# Altium schematic sheet PowerSupplies.SchDoc
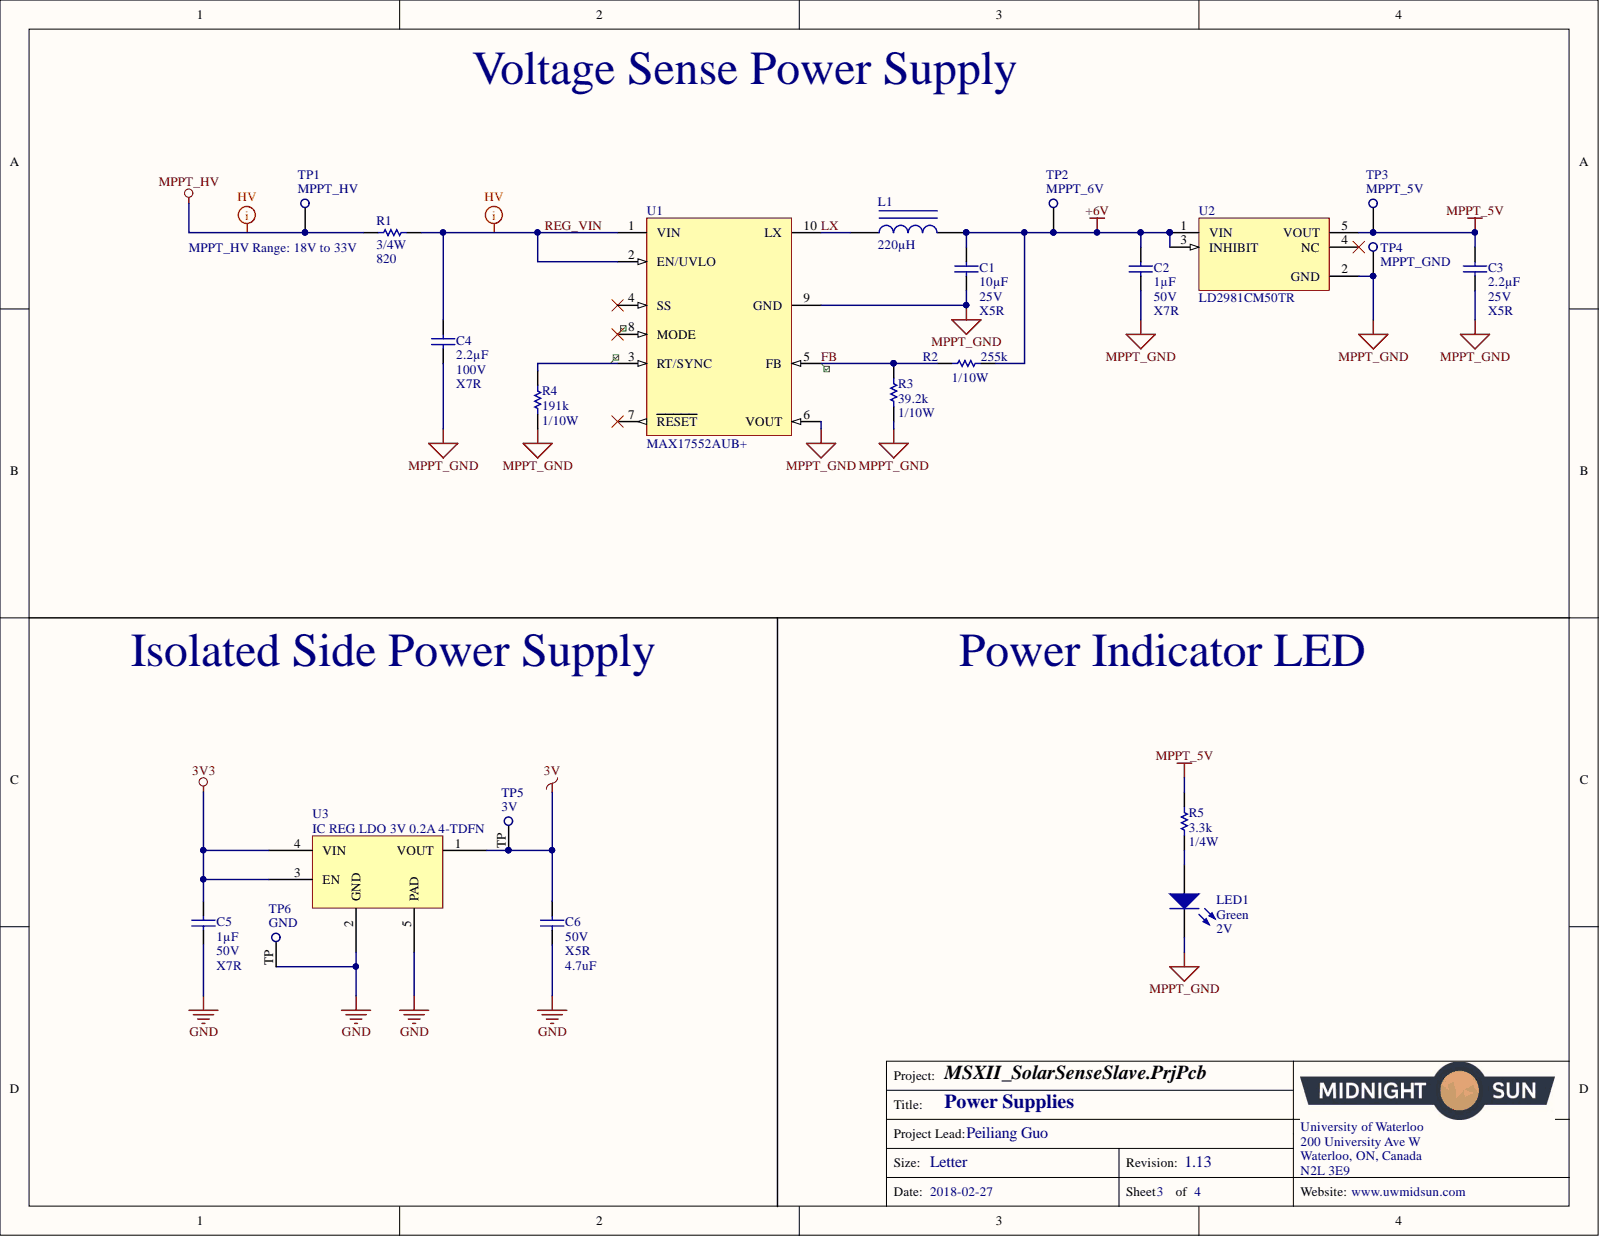
[diagram p0: PowerSupplies.SchDoc]

## Parts
C1  Capacitance: 10µF  Temperature Coefficient: X5R  Voltage: 25V  [CAP, 0603]  (hidden comment: CAP CER 10uF 25V 20% X5R 0603)
C2  Capacitance: 1µF  Temperature Coefficient: X7R  Voltage: 50V  [CAP, 0603]  (hidden comment: CAP CER 1UF 50V 10% X7R 0603)
C3  Capacitance: 2.2µF  Temperature Coefficient: X5R  Voltage: 25V  [CAP, 0603]  (hidden comment: CAP CER 2.2UF 25V 10% X5R 0603)
C4  Capacitance: 2.2µF  Temperature Coefficient: X7R  Voltage: 100V  [CAP, 1206]  (hidden comment: CAP CER 2.2UF 100V ±20% X7R 1206)
C5  Capacitance: 1µF  Temperature Coefficient: X7R  Voltage: 50V  [CAP, 0603]  (hidden comment: CAP CER 1UF 50V 10% X7R 0603)
C6  value 4.7uF  Temperature Coefficient: X5R  Voltage - Rated: 50V  [CAP, 0805]  (hidden comment: CAP CER 4.7UF 50V 10% X5R 0805)
L1  Inductance: 220µH  [INDP6060X23N]  (hidden comment: IND 220uH 0.59A 20%)
LED1  Color: Green  Voltage - Forward (Vf) (Typ): 2V  [LED_0603]  (hidden comment: LED GREEN CLEAR 2V 0603)
R1  Power: 3/4W  [RES 2010]  (hidden comment: RES 820 OHM 5% 3/4W 2010)
R2  Power (Watts): 1/10W  Resistance (Ohms): 255k  [RES, 0603]  (hidden comment: RES 255K OHM 1% 1/10W 0603)
R3  Power: 1/10W  Resistance: 39.2k  [RES, 0603]  (hidden comment: RES 39.2K OHM 1% 1/10W 0603)
R4  Power: 1/10W  Resistance: 191k  [RES, 0603]  (hidden comment: RES 191K OHM 1% 1/10W 0603)
R5  Power: 1/4W  Resistance: 3.3k  [RES, 0603]  (hidden comment: RES 3.3K OHM 1% 1/4W 0603)
TP1  MPPT_HV  [Thru Test Point]
TP2  MPPT_6V  [Thru Test Point]
TP3  MPPT_5V  [Thru Test Point]
TP4  MPPT_GND  [Thru Test Point]
TP5  3V  [Test Point]
TP6  GND  [Test Point]
U1  Manufacturer Part Number 1: MAX17552AUB+  [TSSOP50P490X110-10N]  (hidden comment: IC REG BUCK ADJ 0.1A 10UMAX)
U2  Manufacturer Part Number 1: LD2981CM50TR  [SOT95P280X145-5N]  (hidden comment: IC REG LDO 5V 0.1A SOT23-5)
U3  IC REG LDO 3V 0.2A 4-TDFN  Voltage - Output: 3V  [IC REG LDO 3V 0.2A 4-TDFN]

## Nets
3V: C6.1 TP5.TP U3.1(VOUT)
3V3: C5.1 U3.3(EN) U3.4(VIN)
+6V: C1.1 C2.1 L1.2 R2.2 TP2.TH_TP U2.1(VIN) U2.3(INHIBIT)
GND: C5.2 C6.2 TP6.TP U3.2(GND) U3.5(PAD)
MPPT_5V: C3.1 R5.1 TP3.TH_TP U2.5(VOUT)
MPPT_GND: C1.2 C2.2 C3.2 C4.2 LED1.2 R3.2 R4.2 TP4.TH_TP U1.6(VOUT) U1.9(GND) U2.2(GND)
MPPT_HV: R1.1 TP1.TH_TP
FB: R2.1 R3.1 U1.5(FB)
LX: L1.1 U1.10(LX)
REG_VIN: C4.1 R1.2 U1.1(VIN) U1.2(EN/UVLO)
NetLED1_1: LED1.1 R5.2
NetR4_1: R4.1 U1.3(RT/SYNC)

Not connected: U1 4(SS) 7(RESET) 8(MODE); U2 4(NC)

## Library data of the parts
C1 = CAP CER 10uF 25V 20% X5R 0603: 10µF ±20% 25V Ceramic Capacitor X5R 0603 (1608 Metric); Manufacturer 1: Murata Electronics North America; Manufacturer Part Number 1: GRM188R61E106MA73D; Component Kind: Standard; ComponentLink1Description: http://www.murata.com/~/media/webrenewal/support/library/catalog/products/capacitor/mlcc/c02e.ashx; ComponentLink1URL: http://www.murata.com/~/media/webrenewal/support/library/catalog/products/capacitor/mlcc/c02e.ashx; Height - Seated (Max): -; Lead Spacing: -; Lead Style: -; Mounting Type: Surface Mount, MLCC; Operating Temperature: -55°C ~ 85°C; Package / Case: 0603 (1608 Metric); Packaging: Cut Tape (CT); Part Status: Active; Ratings: -; Size / Dimension: 0.063" L x 0.031" W (1.60mm x 0.80mm); Supplier 1: Digi-Key; Supplier Part Number 1: 490-7202-1-ND; Thickness (Max): 0.031" (0.80mm); Tolerance: ±20%; Library Name: Schematic Diagrams.SchLib
C2 C5 = CAP CER 1UF 50V 10% X7R 0603: 1µF ±10% 50V Ceramic Capacitor X7R 0603 (1608 Metric); Manufacturer 1: Taiyo Yuden; Manufacturer Part Number 1: UMK107AB7105KA-T; Component Kind: Standard; ComponentLink1Description: http://www.yuden.co.jp/productdata/catalog/en/mlcc_all_e.pdf; ComponentLink1URL: http://www.yuden.co.jp/productdata/catalog/en/mlcc_all_e.pdf; Height - Seated (Max): -; Lead Spacing: -; Lead Style: -; Mounting Type: Surface Mount, MLCC; Operating Temperature: -55°C ~ 125°C; Package / Case: 0603 (1608 Metric); Packaging: Cut Tape (CT); Part Status: Active; Ratings: -; Size / Dimension: 0.063" L x 0.031" W (1.60mm x 0.80mm); Supplier 1: Digi-Key; Supplier Part Number 1: 587-3247-1-ND; Thickness (Max): 0.037" (0.95mm); Tolerance: ±10%; Library Name: Schematic Diagrams.SchLib
C3 = CAP CER 2.2UF 25V 10% X5R 0603: 2.2µF ±10% 25V Ceramic Capacitor X5R 0603 (1608 Metric); Manufacturer 1: Murata Electronics North America; Manufacturer Part Number 1: GRM188R61E225KA12D; Component Kind: Standard; ComponentLink1Description: http://www.murata.com/~/media/webrenewal/support/library/catalog/products/capacitor/mlcc/c02e.ashx; ComponentLink1URL: http://www.murata.com/~/media/webrenewal/support/library/catalog/products/capacitor/mlcc/c02e.ashx; Height - Seated (Max): -; Lead Spacing: -; Lead Style: -; Mounting Type: Surface Mount, MLCC; Operating Temperature: -55°C ~ 85°C; Package / Case: 0603 (1608 Metric); Packaging: Cut Tape (CT); Part Status: Active; Ratings: -; Size / Dimension: 0.063" L x 0.031" W (1.60mm x 0.80mm); Supplier 1: Digi-Key; Supplier Part Number 1: 490-10731-1-ND; Thickness (Max): 0.035" (0.90mm); Tolerance: ±10%; Library Name: Schematic Diagrams.SchLib
C4 = CAP CER 2.2UF 100V ±20% X7R 1206: 2.2µF ±20% 100V Ceramic Capacitor X7R 1206 (3216 Metric); Manufacturer 1: Murata Electronics North America; Manufacturer Part Number 1: GRM31CR72A225MA73L; Component Kind: Standard; ComponentLink1Description: http://www.murata.com/~/media/webrenewal/support/library/catalog/products/capacitor/mlcc/c02e.ashx; ComponentLink1URL: http://www.murata.com/~/media/webrenewal/support/library/catalog/products/capacitor/mlcc/c02e.ashx; Height - Seated (Max): -; Lead Spacing: -; Lead Style: -; Mounting Type: Surface Mount, MLCC; Operating Temperature: -55°C ~ 125°C; Package / Case: 1206 (3216 Metric); Packaging: Cut Tape (CT); Part Status: Active; Ratings: -; Size / Dimension: 0.126" L x 0.063" W (3.20mm x 1.60mm); Supplier 1: Digi-Key; Supplier Part Number 1: 490-12773-1-ND; Thickness (Max): 0.071" (1.80mm); Tolerance: ±20%; Library Name: Schematic Diagrams.SchLib
C6 = CAP CER 4.7UF 50V 10% X5R 0805: 4.7µF ±20% 50V Ceramic Capacitor X5R 0805 (2012 Metric); Manufacturer 1: Murata Electronics North America; Manufacturer Part Number 1: GRT21BR61H475ME13L; Description 1: CAP CER 4.7UF 50V X5R 0805; Applications: Automotive; Category: Capacitors; Component Kind: Standard; ComponentLink1Description: http://search.murata.co.jp/Ceramy/image/img/A01X/G101/ENG/GRT21BR61H475ME13-01.pdf; ComponentLink1URL: http://search.murata.co.jp/Ceramy/image/img/A01X/G101/ENG/GRT21BR61H475ME13-01.pdf; Features: -; Height - Seated (Max): -; Lead Spacing: -; Lead Style: -; Mounting Type: Surface Mount, MLCC; Operating Temperature: -55°C ~ 85°C; Package / Case: 0805 (2012 Metric); Packaging: Cut Tape (CT); Part Status: Active; Pricing 1: 1=0.56, 10=0.389, 100=0.2338, 500=0.17536, 1000=0.14808 (CAD); Ratings: AEC-Q200; RoHS: RoHS Compliant; Size / Dimension: 0.079" L x 0.049" W (2.00mm x 1.25mm); Stock 1: 4286; Supplier 1: Digi-Key; Supplier Part Number 1: 490-12395-1-ND; Thickness (Max): 0.057" (1.45mm); Tolerance: ±20%; Library Name: Schematic Diagrams.SchLib
L1 = IND 220uH 0.59A 20%: 220µH Shielded Inductor 590mA 834 mOhm Nonstandard; Manufacturer 1: Laird-Signal Integrity Products; Manufacturer Part Number 1: TYS6045221M-10; Current - Saturation: 700mA; Frequency - Self Resonant: 3.5MHz; Component Kind: Standard; ComponentLink1Description: http://assets.lairdtech.com/home/brandworld/files/TYS6045221M-10.pdf; ComponentLink1URL: http://assets.lairdtech.com/home/brandworld/files/TYS6045221M-10.pdf; Current Rating: 590mA; DC Resistance (DCR): 834 mOhm; Height - Seated (Max): 0.185" (4.70mm); Material - Core: -; Mounting Type: Surface Mount; Operating Temperature: -40°C ~ 125°C; Package / Case: Nonstandard; Packaging: Cut Tape (CT); Part Status: Active; Q @ Freq: -; Ratings: AEC-Q200; Shielding: Shielded; Size / Dimension: 0.236" L x 0.236" W (6.00mm x 6.00mm); Supplier 1: Digi-Key; Supplier Device Package: -; Supplier Part Number 1: 240-2742-1-ND; Tolerance: ±20%; Type: -; Library Name: Schematic Diagrams.SchLib
LED1 = LED GREEN CLEAR 2V 0603: Green 572nm LED Indication - Discrete 2V 0603 (1608 Metric); Manufacturer: Wurth Electronics Inc.; Manufacturer Part Number: 150060VS75000; Current - Test: 20mA; Lens Color: Colorless; Lens Style/Size: Rectangle with Flat Top, 1.10mm x 0.70mm; Millicandela Rating: 40mcd; Viewing Angle: 140°; Wavelength - Dominant: 570nm; Category: Optoelectronics; Component Kind: Standard; ComponentLink1Description: http://katalog.we-online.de/led/datasheet/150060VS75000.pdf; ComponentLink1URL: http://katalog.we-online.de/led/datasheet/150060VS75000.pdf; Features: -; Height (Max): 0.80mm; Lens Transparency: Clear; Mounting Type: Surface Mount; Package / Case: 0603 (1608 Metric); Packaging: Cut Tape (CT); Part Status: Active; Pricing 1: 1=0.21, 100=0.1617, 500=0.13964 (CAD); RoHS: RoHS Compliant; Size / Dimension: 1.60mm L x 0.80mm W; Stock 1: 9408; Supplier 1: Digi-Key; Supplier Device Package: 0603; Supplier Part Number 1: 732-4980-1-ND; Wavelength - Peak: 572nm; Library Name: Schematic Diagrams.SchLib
R1 = RES 820 OHM 5% 3/4W 2010: 820 Ohms ±5% 0.75W, 3/4W Chip Resistor 2010 (5025 Metric) Automotive AEC-Q200 Thick Film; Manufacturer: Stackpole Electronics Inc.; Manufacturer Part Number: RMCF2010JT820R; Resistance: 820; Temperature Coefficient: ±200ppm/°C; Category: Resistors; Component Kind: Standard; ComponentLink1Description: https://www.seielect.com/Catalog/SEI-RMCF_RMCP.pdf; ComponentLink1URL: https://www.seielect.com/Catalog/SEI-RMCF_RMCP.pdf; Composition: Thick Film; Failure Rate: -; Features: Automotive AEC-Q200; Height - Seated (Max): 0.028" (0.70mm); Operating Temperature: -55°C ~ 155°C; Package / Case: 2010 (5025 Metric); Packaging: Cut Tape (CT); Part Status: Active; Power (Watts): 0.75W, 3/4W; Pricing 1: 1=0.35, 10=0.291, 100=0.1135, 1000=0.04752 (CAD); RoHS: RoHS Compliant; Size / Dimension: 0.197" L x 0.098" W (5.00mm x 2.50mm); Stock 1: 9416; Supplier 1: Digi-Key; Supplier Device Package: 2010; Supplier Part Number 1: RMCF2010JT820RCT-ND; Tolerance: ±5%; Library Name: Schematic Diagrams.SchLib
R2 = RES 255K OHM 1% 1/10W 0603: 255k Ohm ±1% 0.1W, 1/10W Chip Resistor 0603 (1608 Metric) Moisture Resistant Thick Film; Manufacturer 1: Yageo; Manufacturer Part Number 1: RC0603FR-07255KL; Description 1: RES SMD 255K OHM 1% 1/10W 0603; Temperature Coefficient: ±100ppm/°C; Category: Resistors; Component Kind: Standard; ComponentLink1Description: http://www.yageo.com/documents/recent/PYu-RC_Group_51_RoHS_L_7.pdf; ComponentLink1URL: http://www.yageo.com/documents/recent/PYu-RC_Group_51_RoHS_L_7.pdf; Composition: Thick Film; Failure Rate: -; Features: Moisture Resistant; Height - Seated (Max): 0.022" (0.55mm); Operating Temperature: -55°C ~ 155°C; Package / Case: 0603 (1608 Metric); Packaging: Cut Tape (CT); Part Status: Active; Pricing 1: 1=0.16, 10=0.022, 100=0.0089, 1000=0.00402, 2500=0.00349 (CAD); RoHS: RoHS Compliant; Size / Dimension: 0.063" L x 0.031" W (1.60mm x 0.80mm); Stock 1: 106564; Supplier 1: Digi-Key; Supplier Device Package: 0603; Supplier Part Number 1: 311-255KHRCT-ND; Tolerance: ±1%; Library Name: Schematic Diagrams.SchLib
R3 = RES 39.2K OHM 1% 1/10W 0603: 39.2k Ohm ±1% 0.1W, 1/10W Chip Resistor 0603 (1608 Metric) Moisture Resistant Thick Film; Manufacturer 1: Yageo; Manufacturer Part Number 1: RC0603FR-0739K2L; Temperature Coefficient: ±100ppm/°C; Component Kind: Standard; ComponentLink1Description: http://www.yageo.com/documents/recent/PYu-RC_Group_51_RoHS_L_7.pdf; ComponentLink1URL: http://www.yageo.com/documents/recent/PYu-RC_Group_51_RoHS_L_7.pdf; Composition: Thick Film; Failure Rate: -; Height - Seated (Max): 0.022" (0.55mm); Operating Temperature: -55°C ~ 155°C; Package / Case: 0603 (1608 Metric); Packaging: Cut Tape (CT); Part Status: Active; Size / Dimension: 0.063" L x 0.031" W (1.60mm x 0.80mm); Supplier 1: Digi-Key; Supplier Device Package: 0603; Supplier Part Number 1: 311-39.2KHRCT-ND; Tolerance: ±1%; Library Name: Schematic Diagrams.SchLib
R4 = RES 191K OHM 1% 1/10W 0603: 191k Ohm ±1% 0.1W, 1/10W Chip Resistor 0603 (1608 Metric) Moisture Resistant Thick Film; Manufacturer 1: Yageo; Manufacturer Part Number 1: RC0603FR-07191KL; Temperature Coefficient: ±100ppm/°C; Component Kind: Standard; ComponentLink1Description: http://www.yageo.com/documents/recent/PYu-RC_Group_51_RoHS_L_7.pdf; ComponentLink1URL: http://www.yageo.com/documents/recent/PYu-RC_Group_51_RoHS_L_7.pdf; Composition: Thick Film; Failure Rate: -; Height - Seated (Max): 0.022" (0.55mm); Operating Temperature: -55°C ~ 155°C; Package / Case: 0603 (1608 Metric); Packaging: Cut Tape (CT); Part Status: Active; Size / Dimension: 0.063" L x 0.031" W (1.60mm x 0.80mm); Supplier 1: Digi-Key; Supplier Device Package: 0603; Supplier Part Number 1: 311-191KHRCT-ND; Tolerance: ±1%; Library Name: Schematic Diagrams.SchLib
R5 = RES 3.3K OHM 1% 1/4W 0603: 3.3k Ohm ±1% 0.25W, 1/4W Chip Resistor 0603 (1608 Metric) Automotive AEC-Q200, Pulse Withstanding Thick Film; Manufacturer 1: Panasonic Electronic Components; Manufacturer Part Number 1: ERJ-PA3F3301V; Temperature Coefficient: ±100ppm/°C; Component Kind: Standard; ComponentLink1Description: https://industrial.panasonic.com/cdbs/www-data/pdf/RDO0000/AOA0000C291.pdf; ComponentLink1URL: https://industrial.panasonic.com/cdbs/www-data/pdf/RDO0000/AOA0000C291.pdf; Composition: Thick Film; Failure Rate: -; Height - Seated (Max): 0.022" (0.55mm); Operating Temperature: -55°C ~ 155°C; Package / Case: 0603 (1608 Metric); Packaging: Cut Tape (CT); Part Status: Active; Size / Dimension: 0.063" L x 0.031" W (1.60mm x 0.80mm); Supplier 1: Digi-Key; Supplier Device Package: 0603; Supplier Part Number 1: P3.3KBYCT-ND; Tolerance: ±1%; Library Name: Schematic Diagrams.SchLib
TP1-TP4 = Thru Test Point: Component Kind: Standard; Library Name: Schematic Diagrams.SchLib
TP5 TP6 = Test Point: Component Kind: Standard; Library Name: Schematic Diagrams.SchLib
U1 = IC REG BUCK ADJ 0.1A 10UMAX: Buck Switching Regulator IC Positive Adjustable 0.8V 1 Output 100mA 10-TFSOP, 10-MSOP (0.118", 3.00mm Width); Manufacturer 1: Maxim Integrated; Frequency - Switching: 100kHz ~ 2.2MHz; Function: Step-Down; Number of Outputs: 1; Synchronous Rectifier: Yes; Voltage - Input (Max): 60V; Voltage - Input (Min): 4V; Voltage - Output (Max): 54V; Component Kind: Standard; ComponentLink1Description: http://datasheets.maximintegrated.com/en/ds/MAX17552.pdf; ComponentLink1URL: http://datasheets.maximintegrated.com/en/ds/MAX17552.pdf; Current - Output: 100mA; Mounting Type: Surface Mount; Operating Temperature: -40°C ~ 125°C (TJ); Output Configuration: Positive; Output Type: Adjustable; Package / Case: 10-TFSOP, 10-MSOP (0.118", 3.00mm Width); Packaging: Tube; Part Status: Active; Supplier 1: Digi-Key; Supplier Device Package: 10-uMAX; Supplier Part Number 1: MAX17552AUB+-ND; Topology: Buck; Voltage - Output (Min/Fixed): 0.8V; Library Name: Schematic Diagrams.SchLib
U2 = IC REG LDO 5V 0.1A SOT23-5: Linear Voltage Regulator IC Positive Fixed Output 5V 100mA SOT-23-5; Manufacturer 1: STMicroelectronics; Voltage - Dropout (Typical): 0.18V @ 100mA; Voltage - Input: Up to 16V; Component Kind: Standard; ComponentLink1Description: http://www.st.com/content/ccc/resource/technical/document/datasheet/group1/b7/b5/a1/83/9c/bd/4a/90/CD00001635/files/CD00001635.pdf/jcr:content/translations/en.CD00001635.pdf; ComponentLink1URL: http://www.st.com/content/ccc/resource/technical/document/datasheet/group1/b7/b5/a1/83/9c/bd/4a/90/CD00001635/files/CD00001635.pdf/jcr:content/translations/en.CD00001635.pdf; Current - Limit (Min): -; Current - Output: 100mA; Mounting Type: Surface Mount; Operating Temperature: -40°C ~ 125°C; Package / Case: SC-74A, SOT-753; Packaging: Cut Tape (CT); Part Status: Active; Regulator Topology: Positive Fixed; Supplier 1: Digi-Key; Supplier Device Package: SOT-23-5; Supplier Part Number 1: 497-7787-1-ND; Voltage - Output: 5V; Library Name: Schematic Diagrams.SchLib
U3 = IC REG LDO 3V 0.2A 4-TDFN: Manufacturer: Microchip Technology; Manufacturer Part Number: MIC94310-PYMT-TR; Current - Limit (Min): 250mA; Current - Output: 200mA; Regulator Topology: Positive Fixed; Voltage - Dropout (Typical): 0.04V @ 200mA; Voltage - Input: Up to 3.6V; Category: Integrated Circuits (ICs); Component Kind: Standard; ComponentLink1Description: http://www.microchip.com/mymicrochip/filehandler.aspx?ddocname=en580409; ComponentLink1URL: http://www.microchip.com/mymicrochip/filehandler.aspx?ddocname=en580409; Mounting Type: Surface Mount; Operating Temperature: -40°C ~ 125°C; Package / Case: 4-UFDFN Exposed Pad; Packaging: Cut Tape (CT); Part Status: Active; Pricing 1: 1=0.45, 25=0.3708, 100=0.3386 (CAD); RoHS: RoHS Compliant; Stock 1: 4802; Supplier 1: Digi-Key; Supplier Device Package: 4-TDFN (1.2x1.6); Library Name: Schematic Diagrams.SchLib
